# Altium schematic sheet DIY_DAP_PCB_Power.SchDoc(DIY_DAP_PCB_Power)
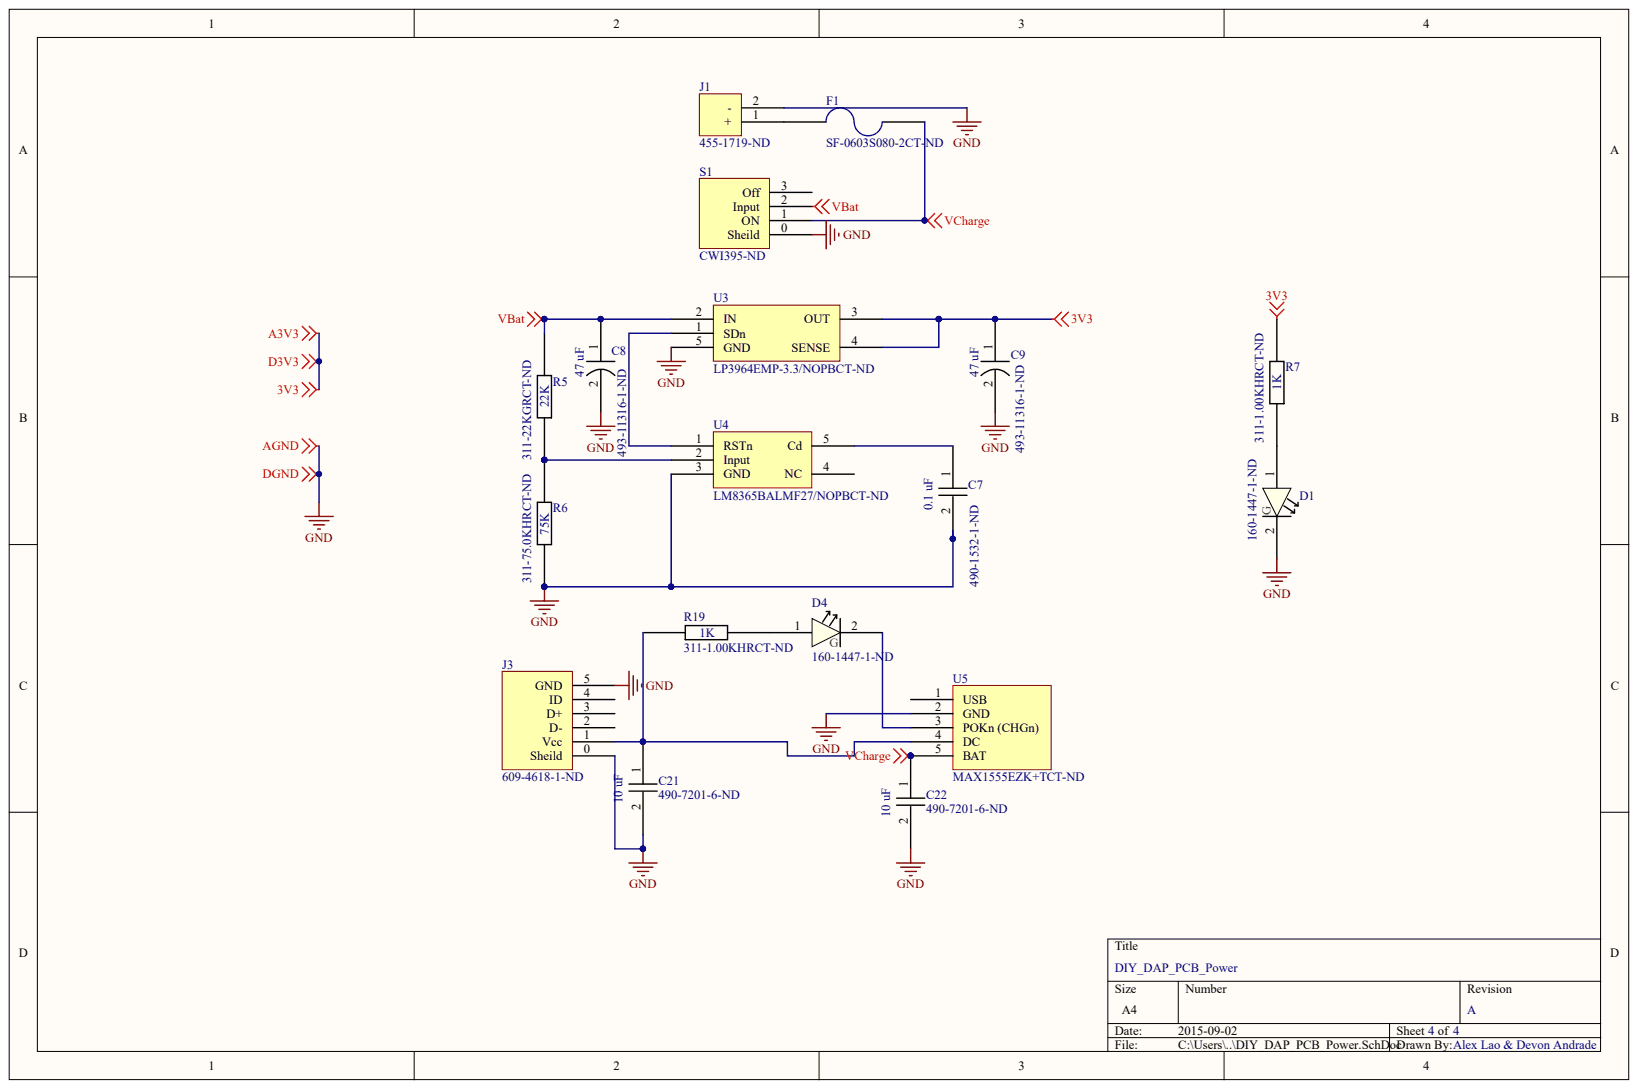
[diagram p0: DIY_DAP_PCB_Power.SchDoc]

## Parts
C7  490-1532-1-ND
C8  493-11316-1-ND
C9  493-11316-1-ND
C21  490-7201-6-ND
C22  490-7201-6-ND
D1  160-1447-1-ND
D4  160-1447-1-ND
F1  SF-0603S080-2CT-ND
J1  455-1719-ND
J3  609-4618-1-ND
R5  311-22KGRCT-ND
R6  311-75.0KHRCT-ND
R7  311-1.00KHRCT-ND
R19  311-1.00KHRCT-ND
S1  CWI395-ND
U3  LP3964EMP-3.3/NOPBCT-ND
U4  LM8365BALMF27/NOPBCT-ND
U5  MAX1555EZK+TCT-ND

## Nets
3V3: C9.1 R7.2 U3.3(OUT) U3.4(SENSE)
AGND: C7.2 C8.2 C9.2 C21.2 C22.2 D1.2 J1.2 J3.0(Sheild) J3.5(GND) R6.2 S1.0(Sheild) U3.5(GND) U4.3(GND) U5.2(GND)
VBAT: C8.1 R5.2 S1.2(Input) U3.2(IN)
VCHARGE: C22.1 F1.2 S1.1(ON) U5.5(BAT)
NetC7_1: C7.1 U4.5(Cd)
NetC21_1: C21.1 J3.1(Vcc) R19.1 U5.4(DC)
NetD1_1: D1.1 R7.1
NetD4_1: D4.1 R19.2
NetD4_2: D4.2 U5.3(POKn_(CHGn))
NetF1_1: F1.1 J1.1
NetR5_1: R5.1 R6.1 U4.2(Input)
NetU3_1: U3.1(SDn) U4.1(RSTn)

Not connected: J3 2(D-) 3(D+) 4(ID); S1 3(Off); U4 4(NC); U5 1(USB)

## Library data of the parts
C7-C9 C21 C22 D1 D4 F1 J1 J3 R5-R7 R19 S1 U3-U5 = (no symbol name): Supplier 1: Digi-Key
